# Altium schematic sheet Info_LEDs.SchDoc(Info_LEDs)
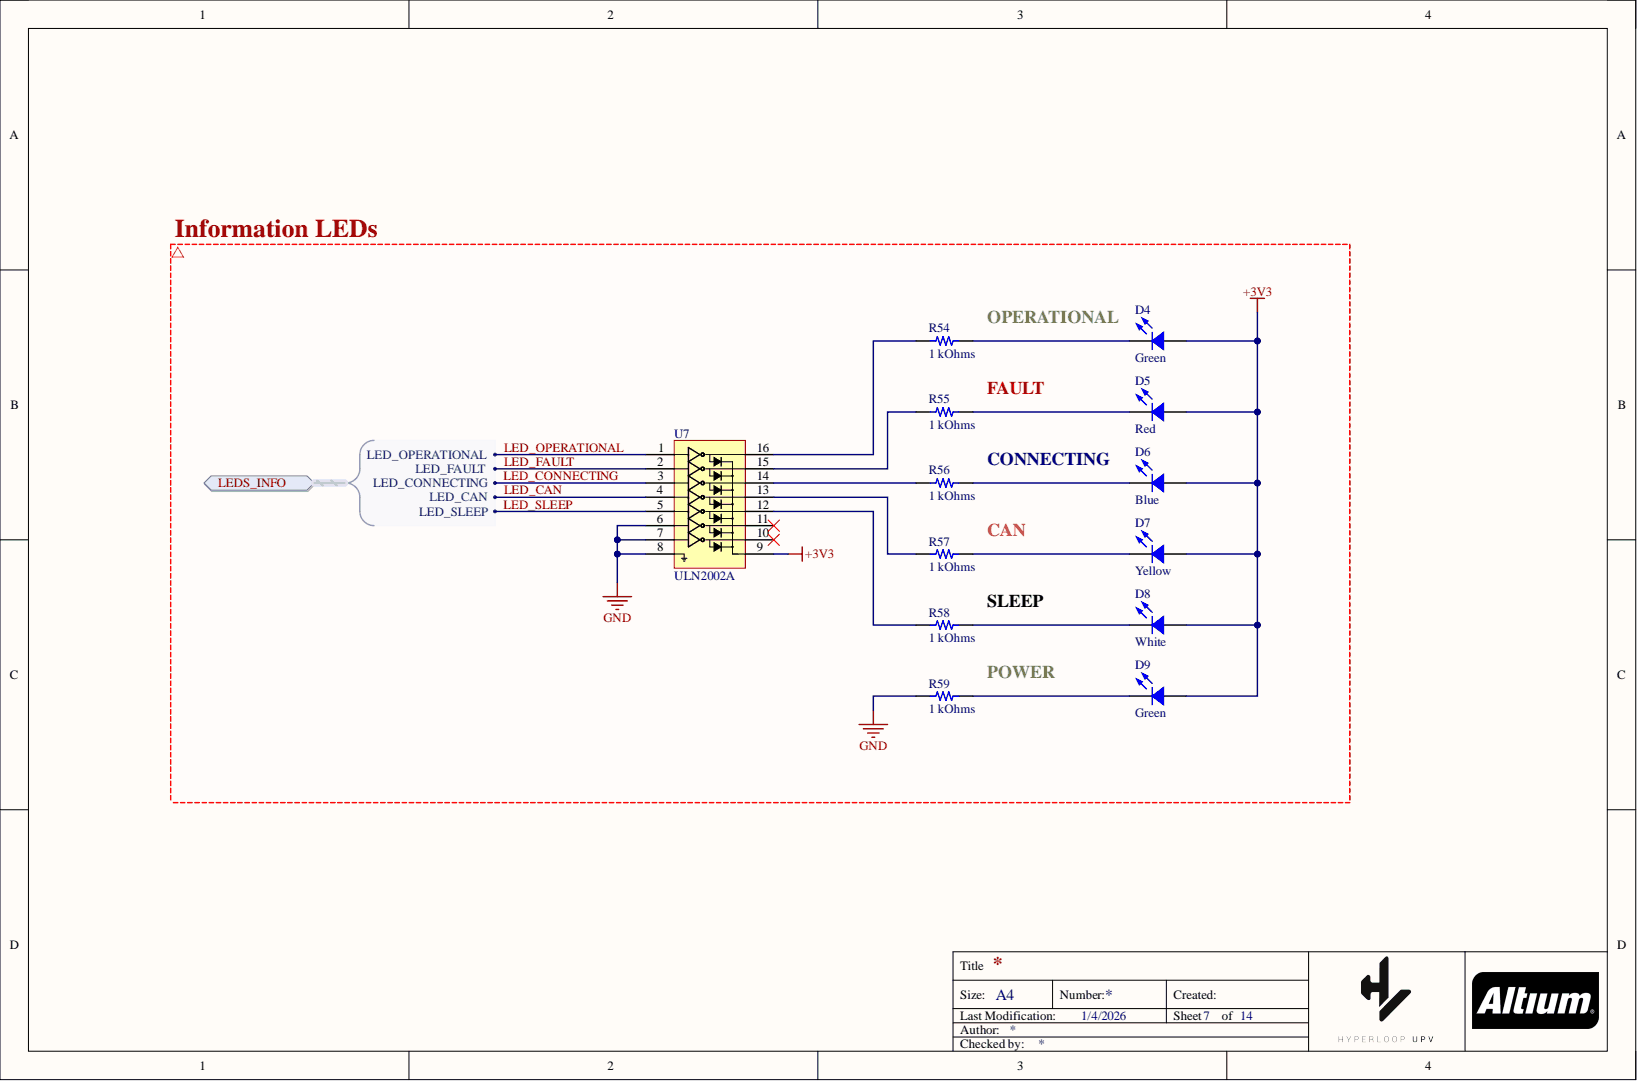
[diagram p0: Info_LEDs.SchDoc]

## Parts
D4  Green
D5  Red
D6  Blue
D7  Yellow
D8  White
D9  Green
R54  1 kOhms
R55  1 kOhms
R56  1 kOhms
R57  1 kOhms
R58  1 kOhms
R59  1 kOhms
U7  ULN2002A

## Ports
LEDS_INFO, LEDS_INFO.LED_CAN, LEDS_INFO.LED_CONNECTING, LEDS_INFO.LED_FAULT, LEDS_INFO.LED_OPERATIONAL, LEDS_INFO.LED_SLEEP

## Nets
+3V3: D4.1 D5.1 D6.1 D7.1 D8.1 D9.1 U7.9
GND: R59.1 U7.6 U7.7 U7.8
LED_CAN: U7.4
LED_CONNECTING: U7.3
LED_FAULT: U7.2
LED_OPERATIONAL: U7.1
LED_SLEEP: U7.5
NetD4_2: D4.2 R54.2
NetD5_2: D5.2 R55.2
NetD6_2: D6.2 R56.2
NetD7_2: D7.2 R57.2
NetD8_2: D8.2 R58.2
NetD9_2: D9.2 R59.2
NetR54_1: R54.1 U7.16
NetR55_1: R55.1 U7.15
NetR56_1: R56.1 U7.14
NetR57_1: R57.1 U7.13
NetR58_1: R58.1 U7.12
N00002: ; port LEDS_INFO
N00004: ; port LEDS_INFO
N00006: ; port LEDS_INFO
N00008: ; port LEDS_INFO
N00010: ; port LEDS_INFO

Not connected: U7 10 11

## Library data of the parts
D4 D9 = VLMTG1300-GS08: LED GREEN 0603 SMD; Manufacturer: Vishay Semiconductor Opto Division; Configuration: Standard; Current - Test: 20mA; Database Table Name: LED - Indication; Lens Color: 1.00mm; Lens Size: 1.10mm x 0.80mm; Lens Style: Rectangle with Flat Top; Millicandela Rating: 260.5mcd; Viewing Angle: 130°; Voltage - Forward (Vf) (Typ): 3.2V; Wavelength - Dominant: 525nm; Wavelength - Peak: 530nm; Color: Green; Component Kind: Standard; ComponentLink1Description: Datasheet; ComponentLink1URL: http://www.vishay.com/docs/82437/vlmo1300.pdf; ComponentLink2Description: DigiKey Link; ComponentLink2URL: https://www.digikey.com/en/products/detail/vishay-semiconductor-opto-division/VLMTG1300-GS08/2813351; Device Package: 0603 (1608 Metric); Height (Max): 1.00mm; LastUpdated: 19/09/2022 13:49:58; Library Name: Celestial Altium Library - altium_library.DbLib; Lifecycle Status: Active; Minimum Order: 1; Mounting Type: Surface Mount; Package / Case: 0603 (1608 Metric); Packaging: TapeAndReel; Part Number: VLMTG1300-GS08; Part Status: Active; PartId: 609; Price: 0.08; Series: CHIPLED; Size / Dimension: 1.60mm L x 0.80mm W; Supplier 1: DigiKey; Supplier Device Package: 0603; Supplier Part Number 1: VLMTG1300-GS08CT-ND; Value: Green
D5 = VLMS1300-GS08: LED RED 0603 SMD; Manufacturer: Vishay Semiconductor Opto Division; Configuration: Standard; Current - Test: 20mA; Database Table Name: LED - Indication; Lens Color: 1.00mm; Lens Size: 1.10mm x 0.80mm; Lens Style: Rectangle with Flat Top; Millicandela Rating: 54mcd; Viewing Angle: 130°; Voltage - Forward (Vf) (Typ): 2V; Wavelength - Dominant: 631nm; Wavelength - Peak: 639nm; Color: Red; Component Kind: Standard; ComponentLink1Description: Datasheet; ComponentLink1URL: http://www.vishay.com/docs/82437/vlmo1300.pdf; ComponentLink2Description: DigiKey Link; ComponentLink2URL: https://www.digikey.com/en/products/detail/vishay-semiconductor-opto-division/VLMS1300-GS08/2813354; Device Package: 0603 (1608 Metric); Height (Max): 1.00mm; LastUpdated: 19/09/2022 13:50:23; Library Name: Celestial Altium Library - altium_library.DbLib; Lifecycle Status: Active; Minimum Order: 1; Mounting Type: Surface Mount; Package / Case: 0603 (1608 Metric); Packaging: TapeAndReel; Part Number: VLMS1300-GS08; Part Status: Active; PartId: 482; Price: 0.04; Series: CHIPLED; Size / Dimension: 1.60mm L x 0.80mm W; Supplier 1: DigiKey; Supplier Device Package: 0603; Supplier Part Number 1: VLMS1300-GS08CT-ND; Value: Red
D6 = VLMB1310-GS08: LED BLUE 0603 SMD; Manufacturer: Vishay Semiconductor Opto Division; Configuration: Standard; Current - Test: 20mA; Database Table Name: LED - Indication; Lens Color: 1.00mm; Lens Size: 1.10mm x 0.80mm; Lens Style: Rectangle with Flat Top; Millicandela Rating: 104mcd; Viewing Angle: 130°; Voltage - Forward (Vf) (Typ): 3.3V; Wavelength - Dominant: 470nm; Wavelength - Peak: 468nm; Color: Blue; Component Kind: Standard; ComponentLink1Description: Datasheet; ComponentLink1URL: http://www.vishay.com/docs/82437/vlmo1300.pdf; ComponentLink2Description: DigiKey Link; ComponentLink2URL: https://www.digikey.com/en/products/detail/vishay-semiconductor-opto-division/VLMB1310-GS08/2813355; Device Package: 0603 (1608 Metric); Height (Max): 1.00mm; LastUpdated: 19/09/2022 13:49:29; Library Name: Celestial Altium Library - altium_library.DbLib; Lifecycle Status: Active; Minimum Order: 1; Mounting Type: Surface Mount; Package / Case: 0603 (1608 Metric); Packaging: TapeAndReel; Part Number: VLMB1310-GS08; Part Status: Active; PartId: 802; Price: 0.08; Series: CHIPLED; Size / Dimension: 1.60mm L x 0.80mm W; Supplier 1: DigiKey; Supplier Device Package: 0603; Supplier Part Number 1: VLMB1310-GS08CT-ND; Value: Blue
D7 = SML-D12Y8WT86: LED YELLOW DIFFUSED 0603 SMD; Manufacturer: Rohm Semiconductor; Configuration: Standard; Current - Test: 20mA; Database Table Name: LED - Indication; Lens Color: White; Lens Size: 1.20mm x 0.80mm; Lens Style: Rectangle with Flat Top; Millicandela Rating: 63mcd; Voltage - Forward (Vf) (Typ): 2.2V; Wavelength - Dominant: 590nm; Color: Yellow; Component Kind: Standard; ComponentLink1Description: Datasheet; ComponentLink1URL: https://www.rohm.com/datasheet/SML-D12V8W; ComponentLink2Description: DigiKey Link; ComponentLink2URL: https://www.digikey.com/en/products/detail/rohm-semiconductor/SML-D12Y8WT86/1641801; Device Package: 0603 (1608 Metric); Height (Max): 0.65mm; LastUpdated: 19/09/2022 13:51:05; Lens Transparency: Diffused; Library Name: Celestial Altium Library - altium_library.DbLib; Lifecycle Status: Active; Minimum Order: 1; Mounting Type: Surface Mount; Package / Case: 0603 (1608 Metric); Packaging: TapeAndReel; Part Number: SML-D12Y8WT86; Part Status: Active; PartId: 110; Price: 0.04; Series: EXCELED™; Size / Dimension: 1.60mm L x 0.80mm W; Supplier 1: DigiKey; Supplier Device Package: 1608 (0603); Supplier Part Number 1: 511-1582-1-ND; Value: Yellow
D8 = CL-198N-WS-D-T: LED WHITE SMD; Manufacturer: Citizen; Configuration: Standard; Current - Test: 5mA; Database Table Name: LED - Indication; Lens Color: 0.25mm; Lens Size: 1.60mm x 0.80mm; Lens Style: Rectangle with Flat Top; Millicandela Rating: 300mcd; Voltage - Forward (Vf) (Typ): 2.9V; Color: White; Component Kind: Standard; ComponentLink1Description: Datasheet; ComponentLink1URL: http://ce.citizen.co.jp/up_img/product/z3ZxekOKAvrY/CL-198N_e.pdf; ComponentLink2Description: DigiKey Link; ComponentLink2URL: https://www.digikey.com/en/products/detail/citizen/CL-198N-WS-D-T/6005907; Device Package: 0603 (1608 Metric); Height (Max): 0.25mm; LastUpdated: 19/09/2022 13:53:58; Library Name: Celestial Altium Library - altium_library.DbLib; Lifecycle Status: Active; Minimum Order: 1; Mounting Type: Surface Mount; Package / Case: 0603 (1608 Metric); Packaging: TapeAndReel; Part Number: CL-198N-WS-D-T; Part Status: Active; PartId: 897; Price: 0.07; Series: CL-198N; Size / Dimension: 1.60mm L x 0.80mm W; Supplier 1: DigiKey; Supplier Part Number 1: 1642-1495-1-ND; Value: White
R54-R59 = RNCS0603BKE10K0: RES 10K OHM 0.1% 1/16W 0603; Manufacturer: Stackpole Electronics Inc; Database Table Name: Resistors - Surface Mount - 0603; Power (Watts): 0.063W, 1/16W; Resistance: 10 kOhms; Temperature Coefficient: ±25ppm/°C; Component Kind: Standard; ComponentLink1Description: Datasheet; ComponentLink1URL: https://www.seielect.com/catalog/sei-rncs_rnch.pdf; ComponentLink2Description: DigiKey Link; ComponentLink2URL: https://www.digikey.com/en/products/detail/stackpole-electronics-inc/RNCS0603BKE10K0/1708836; Composition: Thin Film; Device Package: 0603 (1608 Metric); Features: Anti-Corrosive, Automotive AEC-Q200, Moisture Resistant; Height - Seated (Max): 0.022" (0.55mm); LastUpdated: 09-Feb-24 20:43:37; Library Name: Celestial Altium Library - altium_library.DbLib; Lifecycle Status: Active; Minimum Order: 1; Number of Terminations: 2; Operating Temperature: -55°C ~ 155°C; Package / Case: 0603 (1608 Metric); Packaging: TapeAndReel; Part Number: RNCS0603BKE10K0; Part Status: Active; PartId: 4838; Price: 0.06; Ratings: AEC-Q200; Series: RNCS; Size / Dimension: 0.061" L x 0.031" W (1.55mm x 0.80mm); Supplier 1: DigiKey; Supplier Device Package: 0603; Supplier Part Number 1: RNCS0603BKE10K0CT-ND; Tolerance: ±0.1%; Value: 1 kOhms
U7 = CMP-004-000020-1: ULN200x, ULQ200x High-Voltage, High-Current Darlington Transistor Arrays; Component Kind: Standard; Component Type: Standard; ComponentLink1Description: RS; ComponentLink1URL: https://es.rs-online.com/web/p/pares-darlington/0858196/; ComponentLink2Description: MOUSER; ComponentLink2URL: https://www.mouser.es/ProductDetail/Diodes-Incorporated/ULN2004AS16-13/?qs=54i18ELixbWDuHWYyAaNGg%3D%3D; Design Item ID: ULN2002A; Pin Count: 16; Library Name: Hyperloop UPV; Source: Hyperlibrary.SchLib
